# Altium schematic sheet 505_500051_SRU233_01.02.01.SchDoc(505_500051_SRU233_01.02.01)
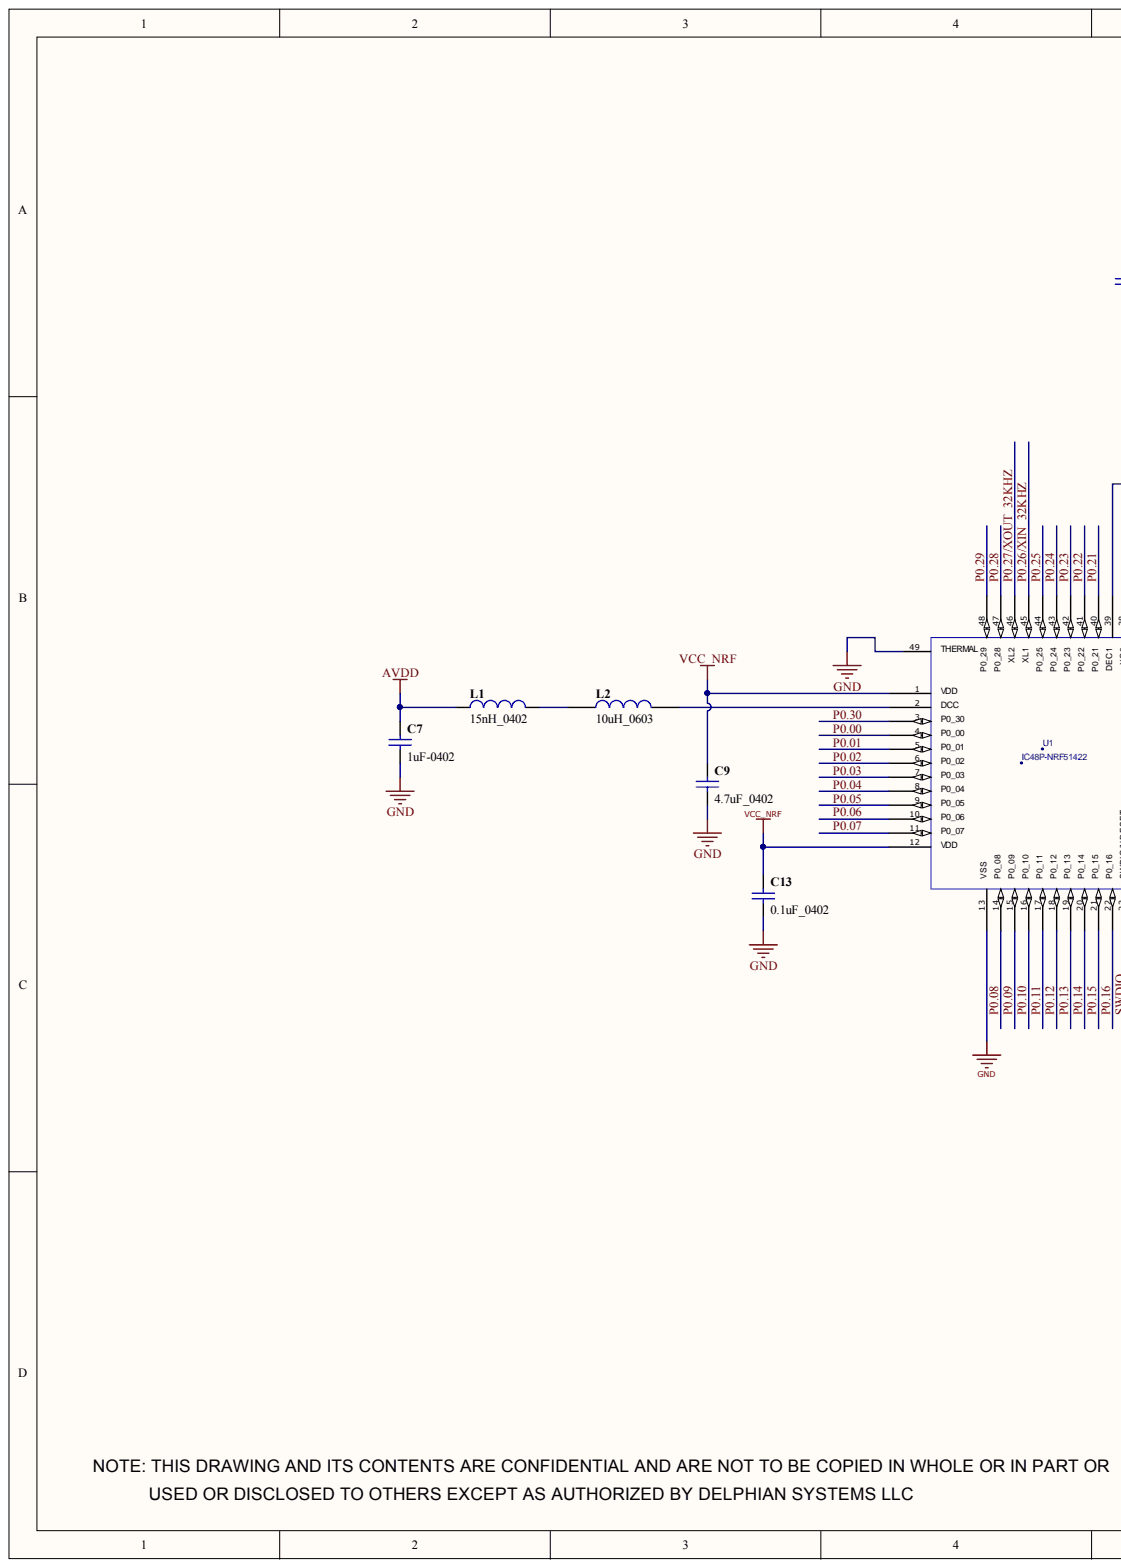
[diagram p0: 505_500051_SRU233_01.02.01.SchDoc, left]
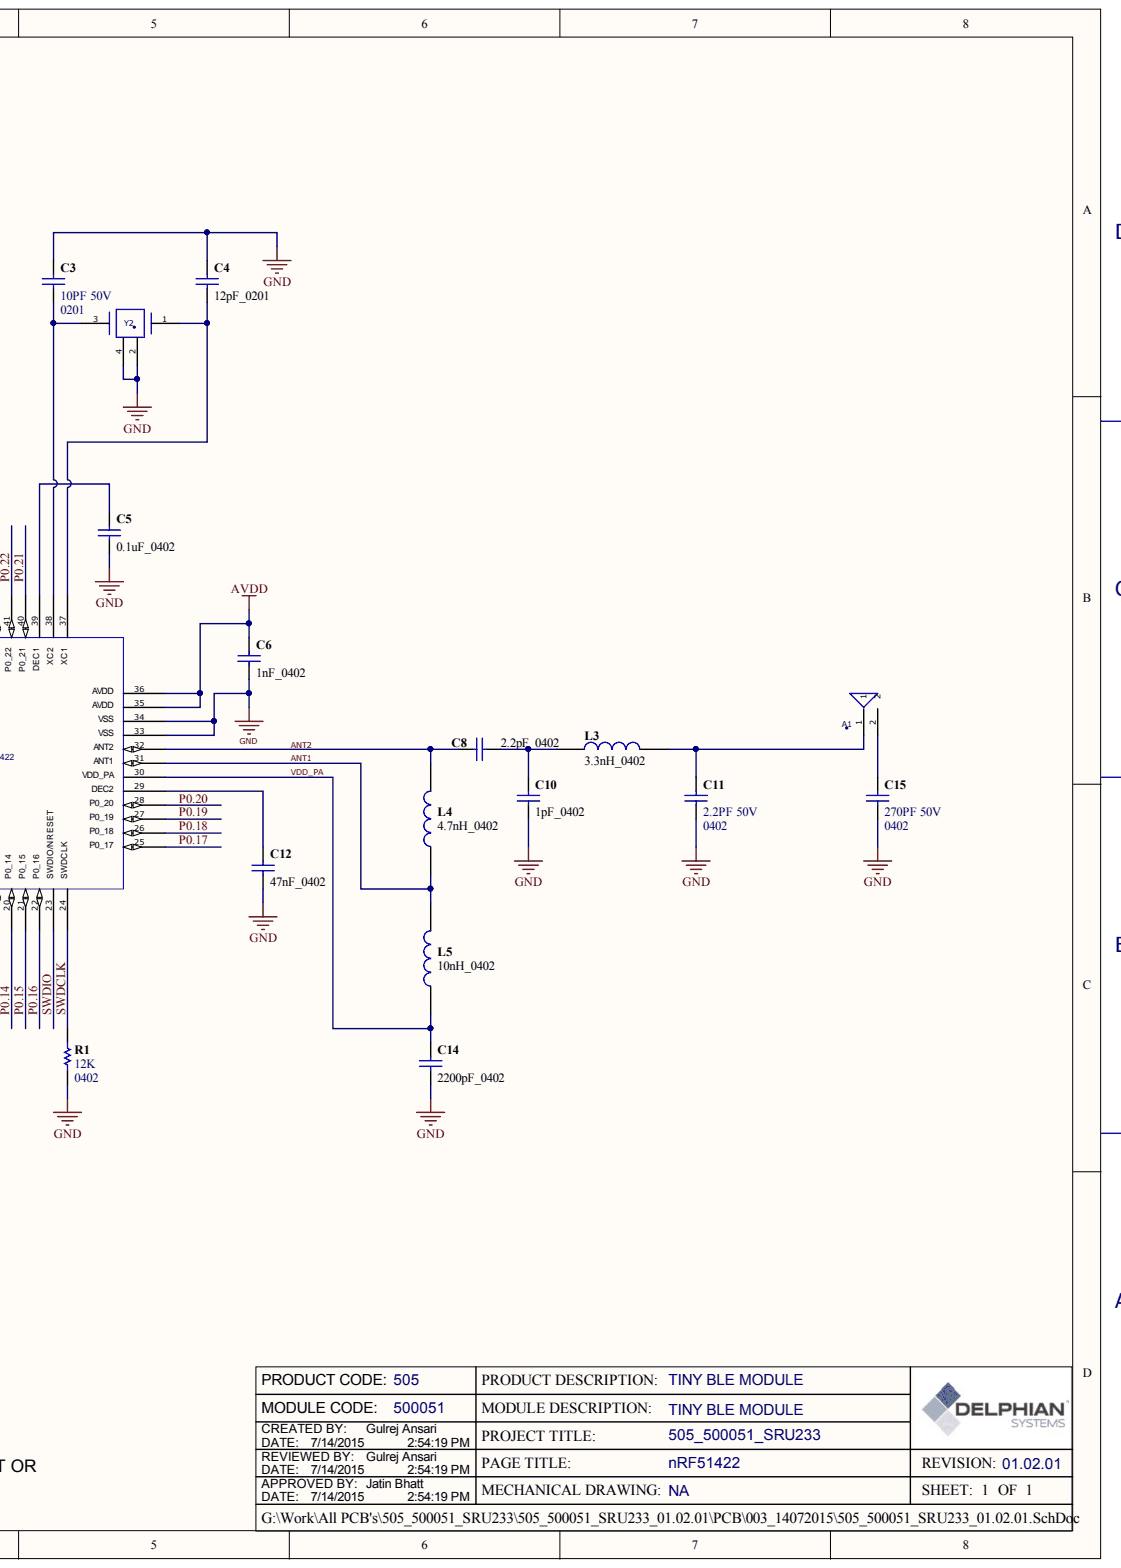
[diagram p1: 505_500051_SRU233_01.02.01.SchDoc, right]

## Parts
A1  ACA-102-T  [ACA-102-T]  (value not drawn)
C3  CS10pF-50V0201  [0201]  (value not drawn)
C4  12pF_0201  [0201]
C5  0.1uF_0402  [0402]
C6  1nF_0402  [0402]
C7  1uF-0402  [0402]
C8  2.2pF_0402  [0402]
C9  4.7uF_0402  [0402]
C10  1pF_0402  [0402]
C11  Part Name: 2.2PF 50V  [0402]  (hidden comment: CS2.2pF-50V0402)
C12  47nF_0402  [0402]
C13  0.1uF_0402  [0402]
C14  2200pF_0402  [0402]
C15  Part Name: 270PF 50V  [0402]  (hidden comment: CS270pF-50V0402)
L1  15nH_0402  [0402]
L2  10uH_0603  [0603]
L3  3.3nH_0402  [0402]
L4  4.7nH_0402  [0402]
L5  10nH_0402  [0402]
R1  value 12K  [0402]  (hidden comment: RS12K-1/4W0402)
U1  IC48P-NRF51422  [QFN-48_0.4MM]
Y2  XS32MHz-ABM10  [ABM10]  (value not drawn)

## Nets
AVDD: C6.1 C7.1 L1.1 U1.35(AVDD) U1.36(AVDD)
GND: C3.1 C4.1 C5.2 C6.2 C7.2 C9.2 C10.2 C11.2 C12.2 C13.2 C14.2 C15.2 R1.1 U1.13(VSS) U1.33(VSS) U1.34(VSS) U1.49(THERMAL) Y2.2 Y2.4
VCC_NRF: C9.1 C13.1 U1.1(VDD) U1.12(VDD)
ANT1: L4.1 L5.2 U1.31(ANT1)
ANT2: C8.1 L4.2 U1.32(ANT2)
P0.00: U1.4(P0_00)
P0.01: U1.5(P0_01)
P0.02: U1.6(P0_02)
P0.03: U1.7(P0_03)
P0.04: U1.8(P0_04)
P0.05: U1.9(P0_05)
P0.06: U1.10(P0_06)
P0.07: U1.11(P0_07)
P0.08: U1.14(P0_08)
P0.09: U1.15(P0_09)
P0.10: U1.16(P0_10)
P0.11: U1.17(P0_11)
P0.12: U1.18(P0_12)
P0.13: U1.19(P0_13)
P0.14: U1.20(P0_14)
P0.15: U1.21(P0_15)
P0.16: U1.22(P0_16)
P0.17: U1.25(P0_17)
P0.18: U1.26(P0_18)
P0.19: U1.27(P0_19)
P0.20: U1.28(P0_20)
P0.21: U1.40(P0_21)
P0.22: U1.41(P0_22)
P0.23: U1.42(P0_23)
P0.24: U1.43(P0_24)
P0.25: U1.44(P0_25)
P0.26/XIN_32KHZ: U1.45(XL1)
P0.27/XOUT_32KHZ: U1.46(XL2)
P0.28: U1.47(P0_28)
P0.29: U1.48(P0_29)
P0.30: U1.3(P0_30)
SWDCLK: R1.2 U1.24(SWDCLK)
SWDIO: U1.23(SWDIO/NRESET)
VDD_PA: C14.1 L5.1 U1.30(VDD_PA)
NetA1_1: A1.1 C11.1 L3.2
NetA1_2: A1.2 C15.1
NetC3_2: C3.2 U1.38(XC2) Y2.3
NetC4_2: C4.2 U1.37(XC1) Y2.1
NetC5_1: C5.1 U1.39(DEC1)
NetC8_2: C8.2 C10.1 L3.1
NetC12_1: C12.1 U1.29(DEC2)
NetL1_2: L1.2 L2.1
NetL2_2: L2.2 U1.2(DCC)

## Library data of the parts
A1 = ACA-102-T: CHIP ANTENNA, BLE, ACA-102-T; Component Kind: Standard; Library Name: STDL.SchLib
C3 = CS10pF-50V0201: CAP CER 10PF 50V 1% NP0 0201; Manufacturer: Murata Electronics North America; Manu Part Number: GRM0335C1H100FA01D; Vendor-1 Part Number: 490-7750-2-ND; Type: SMD; Vendor-1: DIGIKEY; Vendor-2: FARNELL; Component Kind: Standard; Lead Free: YES; Mounting: YES; Part Name: 10PF 50V; PCB Footprint: 0201; RoHS Compliant: YES; Library Name: STDL.SchLib
C4 = CS12pF-50V0201: Capacitor, Ceramic, 12pF 50V 0201; Component Kind: Standard; Library Name: STDL.SchLib
C5 C13 = CS0.1uF-16V0402: Capacitor, Ceramic, 0.1uF 16V 0402; Component Kind: Standard; Library Name: STDL.SchLib
C6 = CS1nF-50V0402: Capacitor, Ceramic, 1nF 50V 0402; Component Kind: Standard; Library Name: STDL.SchLib
C7 = CS1uF-16V0402: Capacitor, Ceramic, 1uF 16V 0402; Component Kind: Standard; Library Name: STDL.SchLib
C8 = CS2.2pF-50V0402: Capacitor, Ceramic, 2.2pF 50V 0402; Component Kind: Standard; Library Name: STDL.SchLib
C9 = CS4.7uF-10V0402: Capacitor, Ceramic, 4.7uF 10V 0402; Component Kind: Standard; Library Name: STDL.SchLib
C10 = CS1pF-50V0402: Capacitor, Ceramic, 1pF 50V 0402; Component Kind: Standard; Library Name: STDL.SchLib
C11 = CS2.2pF-50V0402: Capacitor, Ceramic, 2.2pF 50V 0402; Manufacturer: TDK Corporation; Manu Part Number: C1005C0G1H2R2B; Vendor-1 Part Number: 445-4864-1-ND; Vendor-2 Part Number: 8819645; Type: SMD; Vendor-1: DIGIKEY; Vendor-2: FARNELL; Component Kind: Standard; Lead Free: YES; Mounting: YES; PCB Footprint: 0402; RoHS Compliant: YES; Library Name: STDL.SchLib
C12 = CS47nF-10V0402: Capacitor, Ceramic, 47nF 10V 0402; Component Kind: Standard; Library Name: STDL.SchLib
C14 = CS2200pF-50V0402: Capacitor, Ceramic, 2200pF 16V 0402; Component Kind: Standard; Library Name: STDL.SchLib
C15 = CS270pF-50V0402: CAP CER 270PF 50V 5% NP0 0402; Manufacturer: Murata Electronics North America; Manu Part Number: GRM1555C1H271JA01D; Vendor-1 Part Number: 490-1294-1-ND; Type: SMD; Vendor-1: DIGIKEY; Vendor-2: FARNELL; Component Kind: Standard; Lead Free: YES; Mounting: YES; PCB Footprint: 0402; RoHS Compliant: YES; Library Name: STDL.SchLib
L1 = LS15nH-0402: Inductor, 15nH, , 0402, SMD; Component Kind: Standard; Library Name: STDL.SchLib
L2 = LS10uH-0603: Inductor, 10uH, , 0603, SMD; Component Kind: Standard; Library Name: STDL.SchLib
L3 = LS3.3nH-0402: Inductor, 3.3nH, , 0402, SMD; Component Kind: Standard; Library Name: STDL.SchLib
L4 = LS4.7nH-0402: Inductor, 4.7nH, , 0402, SMD; Component Kind: Standard; Library Name: STDL.SchLib
L5 = LS10nH-0402: Inductor, 10nH, , 0402, SMD; Component Kind: Standard; Library Name: STDL.SchLib
R1 = RS12K-1/16W0402: Resistor, RES 12.0K OHM 1/16W 5% 0402; Component Kind: Standard; Library Name: STDL.SchLib
U1 = IC48P-NRF51422: Multiprotocol ANT/Bluetooth low energy System on Chip; Component Kind: Standard; Library Name: STDL.SchLib
Y2 = XS32MHz-ABM10: CRYSTAL 32MHZ 10PF SMD; Component Kind: Standard; Library Name: STDL.SchLib
